# Altium schematic sheet USB2_Aux.SchDoc(USB2_Aux)
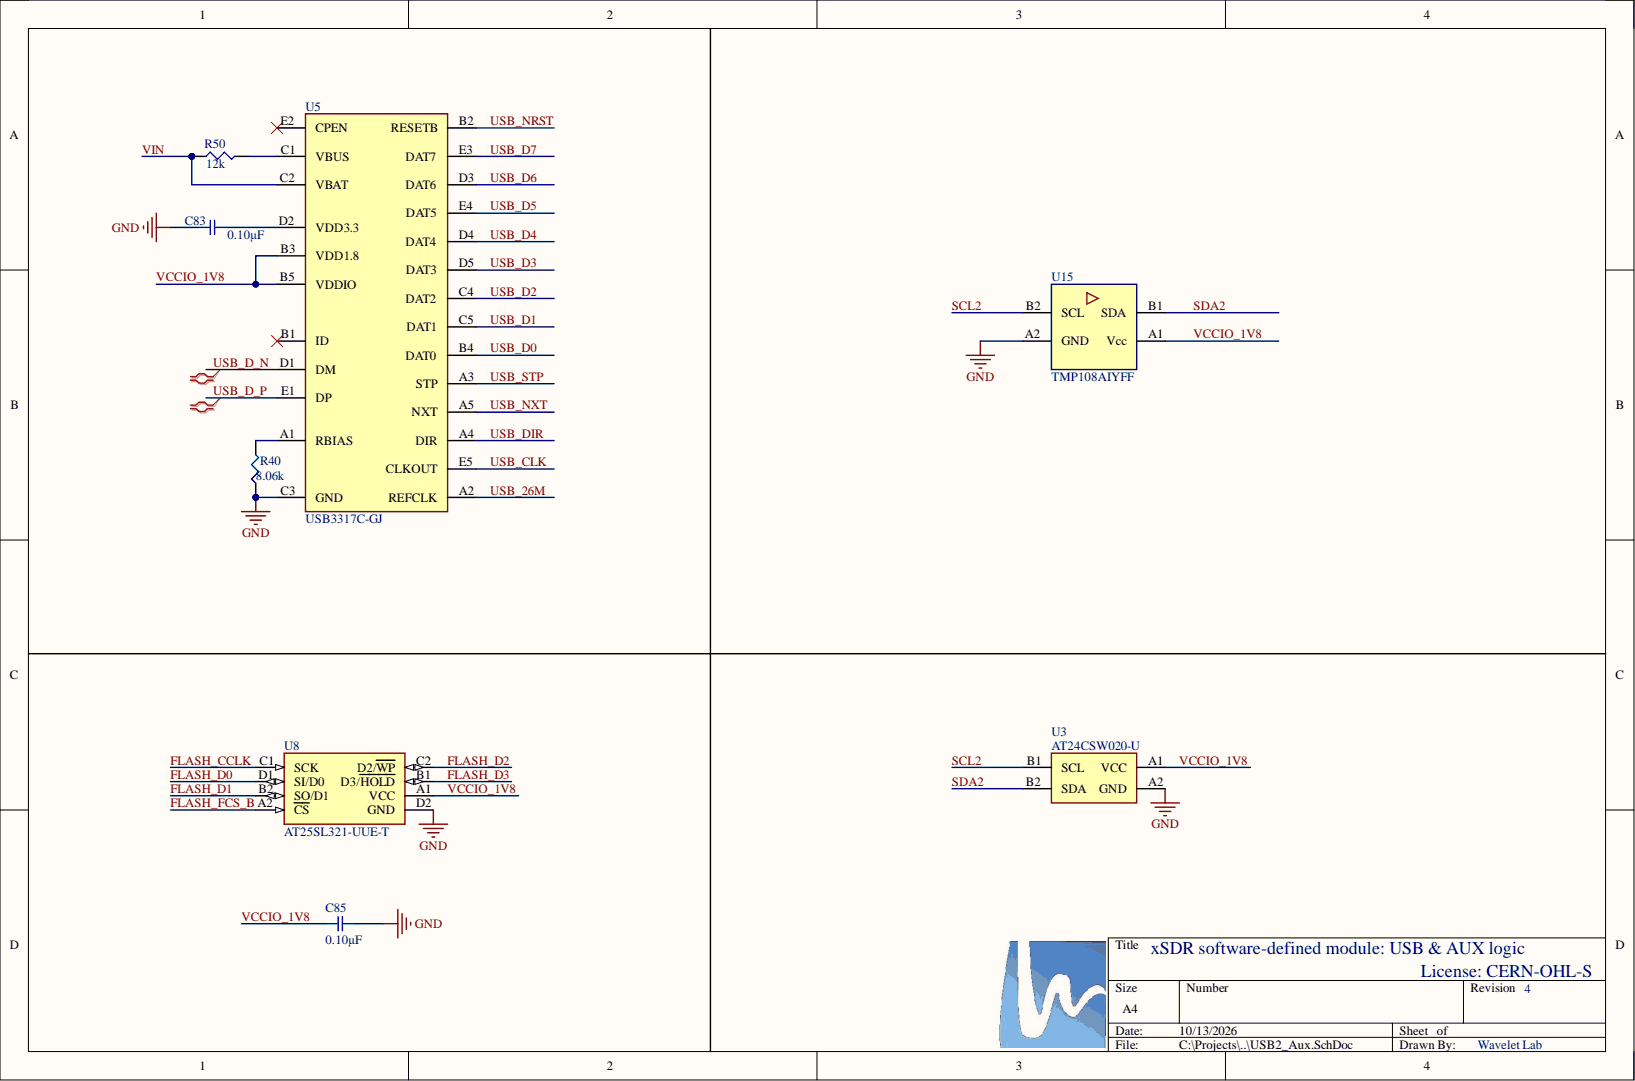
[diagram p0: USB2_Aux.SchDoc]

## Parts
C83  value 0.10µF  [SMD-0201C]
C85  value 0.10µF  [SMD-0201C]
R40  value 8.06k  [SMD-0201-RES]
R50  value 12k  [SMD-0201-RES]
U3  AT24CSW020-U  [BGA WLCSP4]
U5  USB3317C-GJ  [BGA25CP50_5X5_300X300X100B30N]
U8  AT25SL321-UUE-T  [BGA 8WLCSP-A]
U15  TMP108AIYFF  [BGA WCSP4]

## Nets
GND: C83.2 C85.1 R40.1 U3.A2(GND) U5.C3(GND) U8.D2(GND) U15.A2(GND)
FLASH_CCLK: U8.C1(SCK)
FLASH_D0: U8.D1(SI/D0)
FLASH_D1: U8.B2(SO/D1)
FLASH_D2: U8.C2(D2/WP)
FLASH_D3: U8.B1(D3/HOLD)
FLASH_FCS_B: U8.A2(CS)
SCL2: U3.B1(SCL) U15.B2(SCL)
SDA2: U3.B2(SDA) U15.B1(SDA)
USB_26M: U5.A2(REFCLK)
USB_CLK: U5.E5(CLKOUT)
USB_D0: U5.B4(DAT0)
USB_D1: U5.C5(DAT1)
USB_D2: U5.C4(DAT2)
USB_D3: U5.D5(DAT3)
USB_D4: U5.D4(DAT4)
USB_D5: U5.E4(DAT5)
USB_D6: U5.D3(DAT6)
USB_D7: U5.E3(DAT7)
USB_D_N: U5.D1(DM)
USB_D_P: U5.E1(DP)
USB_DIR: U5.A4(DIR)
USB_NRST: U5.B2(RESETB)
USB_NXT: U5.A5(NXT)
USB_STP: U5.A3(STP)
VCCIO_1V8: C85.2 U3.A1(VCC) U5.B3(VDD1.8) U5.B5(VDDIO) U8.A1(VCC) U15.A1(Vcc)
VIN: R50.1 U5.C2(VBAT)
NetC83_1: C83.1 U5.D2(VDD3.3)
NetR40_2: R40.2 U5.A1(RBIAS)
NetR50_2: R50.2 U5.C1(VBUS)

Not connected: U5 B1(ID) E2(CPEN)

## Library data of the parts
C83 C85 = GRM033R60J104ME19D: CAP CER 0.1UF 6.3V X5R 0201; Manufacturer: Murata Electronics North America; @ qty: 0; Minimum Quantity: 1; Tolerance: ±20%; Unit Price (USD): 0.1; Applications: General Purpose; Component Kind: Standard; Datasheets: http://www.murata.com/~/media/webrenewal/support/library/catalog/products/capacitor/mlcc/c02e.pdf; Digi-Key Part Number: 490-5404-1-ND; Factory Stock: 0; Failure Rate: Surface Mount, MLCC; Features: -; Height - Seated (Max): 0.013" (0.33mm); Image: //media.digikey.com/Renders/Murata%20Renders/ZRB-SERIES-0402.jpg; Lead Spacing: -; Mounting Type: 0201 (0603 Metric); Operating Temperature: -55°C ~ 85°C; Package / Case: 0.024" L x 0.012" W (0.60mm x 0.30mm); Packaging: Cut Tape (CT); Part Number: GRM033R60J104ME19D; Part Status: Active; Quantity Available: 381529; Ratings: -; Series: GRM; Size / Dimension: -; Temperature Coefficient: X5R; Thickness (Max): -; Voltage: 6.3V; Library Name: USDR.IntLib
R40 = ERJ-1GEF8061C: RES SMD 8.06K OHM 1% 1/20W 0201; Manufacturer: Panasonic Electronic Components; @ qty: 1500; Factory Stock: 0; Minimum Quantity: 1; Power (Watts): 0.05W, 1/20W; Temperature Coefficient: ±200ppm/°C; Unit Price (USD): 0.00933; Component Kind: Standard; Composition: Thick Film; Datasheets: //media.digikey.com/pdf/Data%20Sheets/Panasonic%20Resistors%20Thermistors%20PDFs/ERJ_G,R,E_Rev08.pdf; Digi-Key Part Number: P8.06KABCT-ND; Failure Rate: -; Features: -; Height - Seated (Max): 0.010" (0.26mm); Image: //media.digikey.com/Renders/Panasonic%20Renders/ERJ-0201%20Pkg.jpg; Number of Terminations: 2; Operating Temperature: -55°C ~ 125°C; Package / Case: 0201 (0603 Metric); Packaging: Cut Tape (CT); Part Number: ERJ-1GEF8061C; Part Status: Active; Quantity Available: 366307; Series: ERJ; Size / Dimension: 0.024" L x 0.012" W (0.60mm x 0.30mm); Supplier Device Package: 0201; Tolerance: ±1%; Library Name: USDR.IntLib
R50 = ERJ-1GEJ123C: RES SMD 12K OHM 5% 1/20W 0201; Manufacturer: Panasonic Electronic Components; @ qty: 1500; Factory Stock: 0; Minimum Quantity: 1; Power (Watts): 0.05W, 1/20W; Temperature Coefficient: ±200ppm/°C; Unit Price (USD): 0.00787; Component Kind: Standard; Composition: Thick Film; Datasheets: //media.digikey.com/pdf/Data%20Sheets/Panasonic%20Resistors%20Thermistors%20PDFs/ERJ%205%25.pdf; Digi-Key Part Number: P12KAGCT-ND; Failure Rate: -; Features: -; Height - Seated (Max): 0.010" (0.26mm); Image: //media.digikey.com/Renders/Panasonic%20Renders/ERJ-0201%20Pkg.jpg; Number of Terminations: 2; Operating Temperature: -55°C ~ 125°C; Package / Case: 0201 (0603 Metric); Packaging: Cut Tape (CT); Part Number: ERJ-1GEJ123C; Part Status: Active; Quantity Available: 6101; Series: ERJ; Size / Dimension: 0.024" L x 0.012" W (0.60mm x 0.30mm); Supplier Device Package: 0201; Tolerance: ±5%; Library Name: USDR.IntLib
U3 = AT24CSW020: IC I2C EEPROM; Manufacturer: Microchip; Part Number: AT24CSW020-UUM0B-T; Misc: 1-Mbit (128Kx8) I2C EEPROM; U/P/TKE: 1.7-3.6V/1MHz/1MWr/-40+85C; Component Kind: Standard; Library Name: IC Digital.IntLib; Link: https://octopart.com/search?autosugg_idx=0&q=AT24CSW020-UUM0B-T&currency=USD&specs=0; Name: AT24CSW020-U; PDF: https://www.lcsc.com/datasheet/C618367.pdf
U5 = USB3317C-GJ: IC TXRX USB 2.0 FLEXPWR 25BGA; Manufacturer: Microchip; Component Kind: Standard; Library Name: IC Digital.IntLib; Name: USB3317C-GJ
U8 = AT25SL321: IC, SPI Flash, 32 Mbit, 8WLCSP-A; Manufacturer: Adesto; U/P/TKE: 1.7-2.0V/-40+85C; Component Kind: Standard; Name: AT25SL321-UUE-T; PDF: https://www2.mouser.com/datasheet/2/590/AT25SL321_112-1385816.pdf; Library Name: USDR.IntLib
U15 = TMP114: IC Thermosensor; Manufacturer: TI; Misc: IC temperature sensor I2C, DSBGA-6; Component Kind: Standard; Link: https://octopart.com/tmp108aiyffr-texas+instruments-28946339; Name: TMP108AIYFF; Library Name: USDR.IntLib
